# Altium schematic sheet Microcontroller.SchDoc
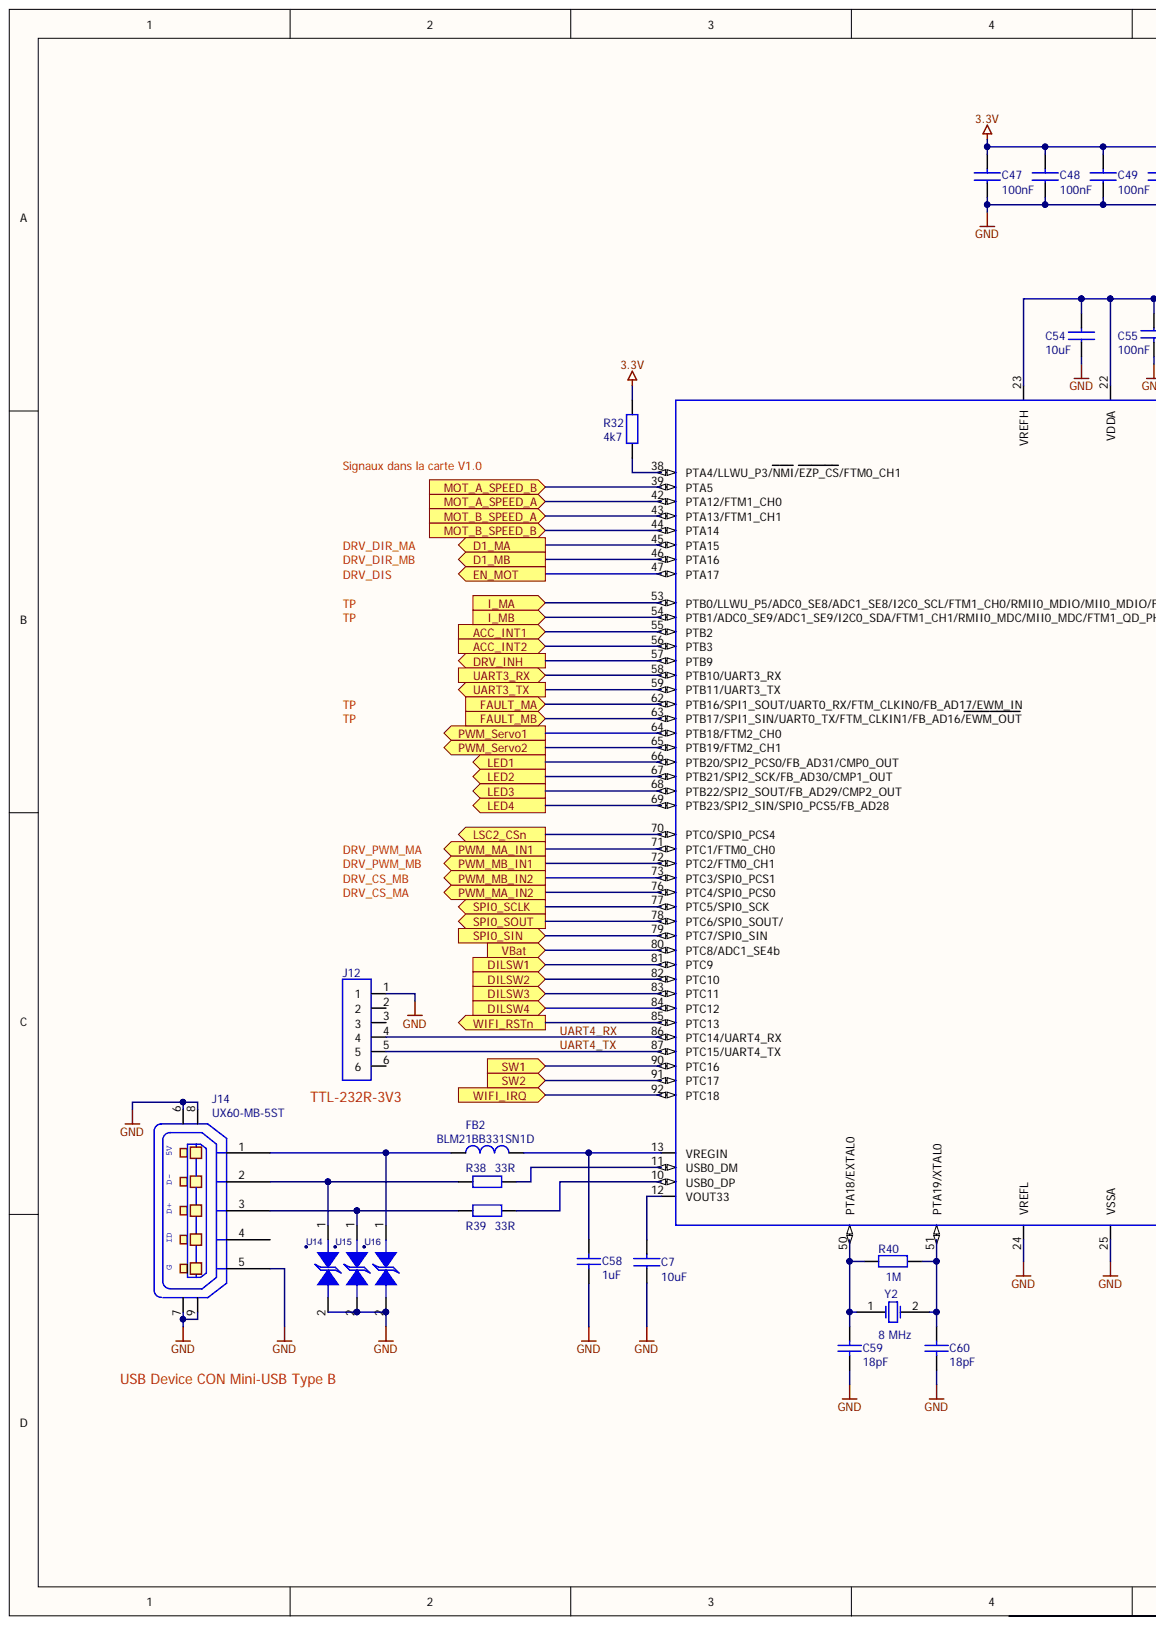
[diagram p0: Microcontroller.SchDoc, left]
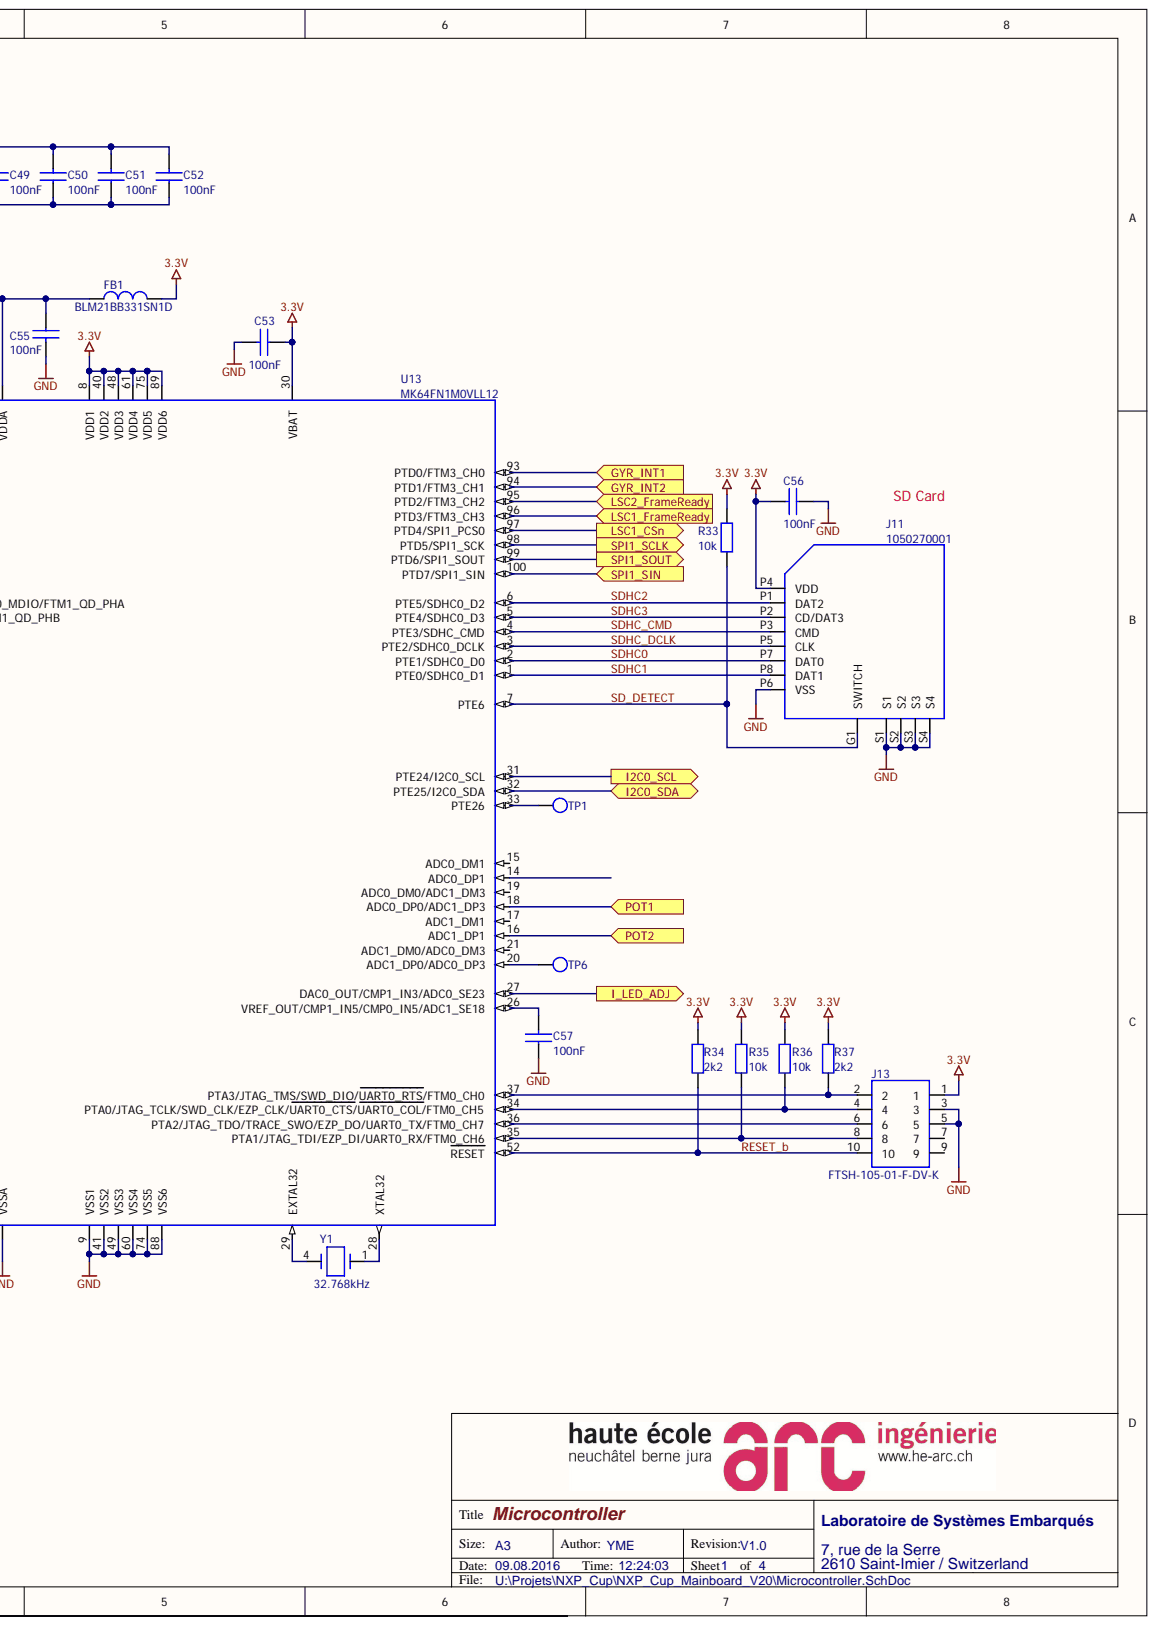
[diagram p1: Microcontroller.SchDoc, right]

## Parts
C7  10uF  [080501]
C47  100nF  [060301]
C48  100nF  [060301]
C49  100nF  [060301]
C50  100nF  [060301]
C51  100nF  [060301]
C52  100nF  [060301]
C53  100nF  [060301]
C54  10uF  [080501]
C55  100nF  [060301]
C56  100nF  [060301]
C57  100nF  [060301]
C58  1uF  [060301]
C59  18pF  [060301]
C60  18pF  [060301]
FB1  BLM21BB331SN1D  [080501]
FB2  BLM21BB331SN1D  [080501]
J11  1050270001  [con8_sdcard_push_sm]
J12  68000-206HLF  [J106A101]  (value not drawn)
J13  FTSH-105-01-F-DV-K  [FTSH-105-01-F-DV-K]
J14  UX60-MB-5ST  [USB/UX60-MB-5ST]
R32  4k7  [060301]
R33  10k  [060301]
R34  2k2  [060301]
R35  10k  [060301]
R36  10k  [060301]
R37  2k2  [060301]
R38  33R  [060301]
R39  33R  [060301]
R40  1M  [060301]
TP1  Test point  [TP_06_10]  (value not drawn)
TP6  Test point  [TP_06_10]  (value not drawn)
U13  MK64FN1M0VLL12  [LQFP100_0P5_14X14]
U14  0402ESDA-MLP  [0402_mlp]  (value not drawn)
U15  0402ESDA-MLP  [0402_mlp]  (value not drawn)
U16  0402ESDA-MLP  [0402_mlp]  (value not drawn)
Y1  value 32.768kHz  [XT-5]  (hidden comment: ECS-.327-12.5-17X-C-TR)
Y2  value 8 MHz  [XT8_2-SMD]  (hidden comment: ABM3-8.000MHZ-D2Y-T)

## Ports
ACC_INT1, ACC_INT2, D1_MA, D1_MB, DILSW1, DILSW2, DILSW3, DILSW4, DRV_INH, EN_MOT, FAULT_MA, FAULT_MB, GYR_INT1, GYR_INT2, I2C0_SCL, I2C0_SDA, I_LED_ADJ, I_MA, I_MB, LED1, LED2, LED3, LED4, LSC1_CSN, LSC1_FRAMEREADY, LSC2_CSN, LSC2_FRAMEREADY, MOT_A_SPEED_A, MOT_A_SPEED_B, MOT_B_SPEED_A, MOT_B_SPEED_B, POT1, POT2, PWM_MA_IN1, PWM_MA_IN2, PWM_MB_IN1, PWM_MB_IN2, PWM_SERVO1, PWM_SERVO2, SPI0_SCLK, SPI0_SIN, SPI0_SOUT, SPI1_SCLK, SPI1_SIN, SPI1_SOUT, SW1, SW2, UART3_RX, UART3_TX, VBAT, WIFI_IRQ, WIFI_RSTN

## Nets
3.3V: C47.2 C48.2 C49.2 C50.2 C51.2 C52.2 C53.1 C56.2 FB1.2 J11.P4(VDD) J13.1 R32.2 R33.1 R34.2 R35.1 R36.1 R37.2 U13.8(VDD1) U13.30(VBAT) U13.40(VDD2) U13.48(VDD3) U13.61(VDD4) U13.75(VDD5) U13.89(VDD6)
GND: C7.1 C47.1 C48.1 C49.1 C50.1 C51.1 C52.1 C53.2 C54.1 C55.2 C56.1 C57.1 C58.2 C59.1 C60.1 J11.P6(VSS) J11.S1 J11.S2 J11.S3 J11.S4 J12.1 J13.3 J13.5 J14.5 J14.6 J14.7 J14.8 J14.9 U13.9(VSS1) U13.24(VREFL) U13.25(VSSA) U13.41(VSS2) U13.49(VSS3) U13.60(VSS4) U13.74(VSS5) U13.88(VSS6) U14.2 U15.2 U16.2
LSC1_CSN: U13.97(PTD4/SPI1_PCS0) ; port LSC1_CSN
LSC1_FRAMEREADY: U13.96(PTD3/FTM3_CH3) ; port LSC1_FRAMEREADY
LSC2_CSN: U13.70(PTC0/SPI0_PCS4) ; port LSC2_CSN
LSC2_FRAMEREADY: U13.95(PTD2/FTM3_CH2) ; port LSC2_FRAMEREADY
PWM_SERVO1: U13.64(PTB18/FTM2_CH0) ; port PWM_SERVO1
PWM_SERVO2: U13.65(PTB19/FTM2_CH1) ; port PWM_SERVO2
VBAT: U13.80(PTC8/ADC1_SE4b) ; port VBAT
WIFI_RSTN: U13.85(PTC13) ; port WIFI_RSTN
ACC_INT1: U13.55(PTB2) ; port ACC_INT1
ACC_INT2: U13.56(PTB3) ; port ACC_INT2
D1_MA: U13.45(PTA15) ; port D1_MA
D1_MB: U13.46(PTA16) ; port D1_MB
DILSW1: U13.81(PTC9) ; port DILSW1
DILSW2: U13.82(PTC10) ; port DILSW2
DILSW3: U13.83(PTC11) ; port DILSW3
DILSW4: U13.84(PTC12) ; port DILSW4
DRV_INH: U13.57(PTB9) ; port DRV_INH
EN_MOT: U13.47(PTA17) ; port EN_MOT
FAULT_MA: U13.62(PTB16/SPI1_SOUT/UART0_RX/FTM_CLKIN0/FB_AD17/EWM_IN) ; port FAULT_MA
FAULT_MB: U13.63(PTB17/SPI1_SIN/UART0_TX/FTM_CLKIN1/FB_AD16/EWM_OUT) ; port FAULT_MB
GYR_INT1: U13.93(PTD0/FTM3_CH0) ; port GYR_INT1
GYR_INT2: U13.94(PTD1/FTM3_CH1) ; port GYR_INT2
I2C0_SCL: U13.31(PTE24/I2C0_SCL) ; port I2C0_SCL
I2C0_SDA: U13.32(PTE25/I2C0_SDA) ; port I2C0_SDA
I_LED_ADJ: U13.27(DAC0_OUT/CMP1_IN3/ADC0_SE23) ; port I_LED_ADJ
I_MA: U13.53(PTB0/LLWU_P5/ADC0_SE8/ADC1_SE8/I2C0_SCL/FTM1_CH0/RMII0_MDIO/MII0_MDIO/FTM1_QD_PHA) ; port I_MA
I_MB: U13.54(PTB1/ADC0_SE9/ADC1_SE9/I2C0_SDA/FTM1_CH1/RMII0_MDC/MII0_MDC/FTM1_QD_PHB) ; port I_MB
LED1: U13.66(PTB20/SPI2_PCS0/FB_AD31/CMP0_OUT) ; port LED1
LED2: U13.67(PTB21/SPI2_SCK/FB_AD30/CMP1_OUT) ; port LED2
LED3: U13.68(PTB22/SPI2_SOUT/FB_AD29/CMP2_OUT) ; port LED3
LED4: U13.69(PTB23/SPI2_SIN/SPI0_PCS5/FB_AD28) ; port LED4
MOT_A_SPEED_A: U13.42(PTA12/FTM1_CH0) ; port MOT_A_SPEED_A
MOT_A_SPEED_B: U13.39(PTA5) ; port MOT_A_SPEED_B
MOT_B_SPEED_A: U13.43(PTA13/FTM1_CH1) ; port MOT_B_SPEED_A
MOT_B_SPEED_B: U13.44(PTA14) ; port MOT_B_SPEED_B
POT1: U13.18(ADC0_DP0/ADC1_DP3) ; port POT1
POT2: U13.16(ADC1_DP1) ; port POT2
PWM_MA_IN1: U13.71(PTC1/FTM0_CH0) ; port PWM_MA_IN1
PWM_MA_IN2: U13.76(PTC4/SPI0_PCS0) ; port PWM_MA_IN2
PWM_MB_IN1: U13.72(PTC2/FTM0_CH1) ; port PWM_MB_IN1
PWM_MB_IN2: U13.73(PTC3/SPI0_PCS1) ; port PWM_MB_IN2
RESET_B: J13.10 R34.1 U13.52(RESET)
SD_DETECT: J11.G1(SWITCH) R33.2 U13.7(PTE6)
SDHC0: J11.P7(DAT0) U13.2(PTE1/SDHC0_D0)
SDHC1: J11.P8(DAT1) U13.1(PTE0/SDHC0_D1)
SDHC2: J11.P1(DAT2) U13.6(PTE5/SDHC0_D2)
SDHC3: J11.P2(CD/DAT3) U13.5(PTE4/SDHC0_D3)
SDHC_CMD: J11.P3(CMD) U13.4(PTE3/SDHC_CMD)
SDHC_DCLK: J11.P5(CLK) U13.3(PTE2/SDHC0_DCLK)
SPI0_SCLK: U13.77(PTC5/SPI0_SCK) ; port SPI0_SCLK
SPI0_SIN: U13.79(PTC7/SPI0_SIN) ; port SPI0_SIN
SPI0_SOUT: U13.78(PTC6/SPI0_SOUT/) ; port SPI0_SOUT
SPI1_SCLK: U13.98(PTD5/SPI1_SCK) ; port SPI1_SCLK
SPI1_SIN: U13.100(PTD7/SPI1_SIN) ; port SPI1_SIN
SPI1_SOUT: U13.99(PTD6/SPI1_SOUT) ; port SPI1_SOUT
SW1: U13.90(PTC16) ; port SW1
SW2: U13.91(PTC17) ; port SW2
UART3_RX: U13.58(PTB10/UART3_RX) ; port UART3_RX
UART3_TX: U13.59(PTB11/UART3_TX) ; port UART3_TX
UART4_RX: J12.4 U13.86(PTC14/UART4_RX)
UART4_TX: J12.5 U13.87(PTC15/UART4_TX)
WIFI_IRQ: U13.92(PTC18) ; port WIFI_IRQ
NetC7_2: C7.2 U13.12(VOUT33)
NetC54_2: C54.2 C55.1 FB1.1 U13.22(VDDA) U13.23(VREFH)
NetC57_2: C57.2 U13.26(VREF_OUT/CMP1_IN5/CMP0_IN5/ADC1_SE18)
NetC58_1: C58.1 FB2.2 U13.13(VREGIN)
NetC59_2: C59.2 R40.1 U13.50(PTA18/EXTAL0) Y2.1
NetC60_2: C60.2 R40.2 U13.51(PTA19/XTAL0) Y2.2
NetFB2_1: FB2.1 J14.1 U16.1
NetJ13_2: J13.2 R37.1 U13.37(PTA3/JTAG_TMS/SWD_DIO/UART0_RTS/FTM0_CH0)
NetJ13_4: J13.4 R36.2 U13.34(PTA0/JTAG_TCLK/SWD_CLK/EZP_CLK/UART0_CTS/UART0_COL/FTM0_CH5)
NetJ13_6: J13.6 U13.36(PTA2/JTAG_TDO/TRACE_SWO/EZP_DO/UART0_TX/FTM0_CH7)
NetJ13_8: J13.8 R35.2 U13.35(PTA1/JTAG_TDI/EZP_DI/UART0_RX/FTM0_CH6)
NetJ14_2: J14.2 R38.1 U14.1
NetJ14_3: J14.3 R39.1 U15.1
NetR32_1: R32.1 U13.38(PTA4/LLWU_P3/NMI/EZP_CS/FTM0_CH1)
NetR38_2: R38.2 U13.11(USB0_DM)
NetR39_2: R39.2 U13.10(USB0_DP)
NetTP1_1: TP1.1 U13.33(PTE26)
NetTP6_1: TP6.1 U13.20(ADC1_DP0/ADC0_DP3)
NetU13_28: U13.28(XTAL32) Y1.1
NetU13_29: U13.29(EXTAL32) Y1.4

Not connected: J12 2 3 6; J13 7 9; J14 4; U13 14(ADC0_DP1) 15(ADC0_DM1) 17(ADC1_DM1) 19(ADC0_DM0/ADC1_DM3) 21(ADC1_DM0/ADC0_DM3)

## Library data of the parts
C7 C54 = CAPA: CAP CER 10UF 16V 20% X6S 0805; Component Kind: Standard; Supplier 1: Digi-Key; Supplier Part Number 1: 445-7612-2-ND; Value: 10uF; Library Name: EISI.SchLib
  C54: Signal Integrity: Cap Semi; Simulation: CAP
C47-C53 C55-C57 = CAPA: CAP CER 0.1UF 25V 10% X7R 0603; Component Kind: Standard; Supplier 1: Digi-Key; Supplier Part Number 1: 445-1316-1-ND; Value: 100nF; Voltage: 25V; Library Name: EISI.SchLib
C58 = Cap Semi: CAP CER 1UF 16V 10% X7R 0603; Component Kind: Standard; Library Name: Miscellaneous Devices.IntLib; Signal Integrity: Cap Semi; Simulation: CAP; Supplier 1: Digi-Key; Supplier Part Number 1: 399-7847-6-ND; Value: 1uF
C59 C60 = Cap Semi: CAP CER 18PF 50V 5% NP0 0603; Component Kind: Standard; Library Name: Miscellaneous Devices.IntLib; Signal Integrity: Cap Semi; Simulation: CAP; Supplier 1: Digi-Key; Supplier Part Number 1: 399-1052-6-ND; Value: 18pF
FB1 FB2 = Ferrite Bead: EMI Filter Beads, Chips & Arrays 0805 330 OHM; Value: 300R@100MHz; Component Kind: Standard; Supplier 1: Mouser; Supplier Part Number 1: 81-BLM21BB331SN1D; Library Name: EISI.SchLib
J11 = CON8_SDCARD_SW: CON 8 SD CARD PUSH SMT 1.1MM SP 75H AU; Component Kind: Standard; Library Name: SCH-28163-1.SCHLIB; Supplier 1: Digi-Key; Supplier Part Number 1: WM6825CT-ND
J12 = CON6: CONN HEADER 6POS .100 STR 15AU; Component Kind: Standard; Supplier 1: Digi-Key; Supplier Part Number 1: 609-3256-ND; Library Name: EISI.SchLib
J13 = CON10A: CONN HEADER 10POS DUAL .05" SMD; Component Kind: Standard; Supplier 1: Digi-Key; Supplier Part Number 1: FTSH-105-01-F-DV-K-ND; Library Name: EISI.SchLib
J14 = USB_MINI_AB_RECEPTACLE: CONN RECEPT MINI USB2.0 5POS; Component Kind: Standard; Supplier 1: Digi-Key; Supplier Part Number 1: H2959CT-ND
R32 = RES: RES 4.7K OHM 1/10W 1% 0603 SMD; Component Kind: Standard; Supplier 1: Digi-Key; Supplier Part Number 1: P4.70KHTR-ND; Value: 4k7; Library Name: EISI.SchLib
R33 R35 R36 = RES: RES 10K OHM 1/10W .1% 0603 SMD; Component Kind: Standard; Supplier 1: Digi-Key; Supplier Part Number 1: P10KDBTR-ND; Value: 10k; Library Name: EISI.SchLib
R34 R37 = RES: RES 2.20K OHM 1/10W 1% 0603 SMD; Component Kind: Standard; Supplier 1: Digi-Key; Supplier Part Number 1: 311-2.20KHRCT-ND; Value: 2k2; Library Name: EISI.SchLib
R38 R39 = RES: RES 33.0 OHM 1/10W 1% 0603 SMD; Component Kind: Standard; Supplier 1: Digi-Key; Supplier Part Number 1: 311-33.0HRCT-ND; Value: 33R; Library Name: EISI.SchLib
R40 = RES: RES 1.00M OHM 1/10W 1% 0603 SMD; Component Kind: Standard; Supplier 1: Digi-Key; Supplier Part Number 1: 311-1.00MHRCT-ND; Value: 1M; Library Name: EISI.SchLib
TP1 TP6 = TP: Component Kind: Standard; Description: Test point; DNP: DNP; Library Name: EISI.SchLib
U13 = K64FN1M0VLL12_100P: IC MCU 1MB FLASH 120MHZ 1.71-3.6V LQFP100; Component Kind: Standard; Library Name: SCH-28163-1.SCHLIB; Supplier 1: Digi-Key; Supplier Part Number 1: MK64FN1M0VLL12-ND
U14-U16 = DIODE_TVS_BIDIR: SUPPRESSOR ESD 30VDC 0402 SMD; Component Kind: Standard; Supplier 1: Digi-Key; Supplier Part Number 1: 283-2792-1-ND
Y1 = Crystal_32: CRYSTAL 32.768 KHZ 12.5PF SMD; Component Kind: Standard; Supplier 1: Digi-Key; Supplier Part Number 1: XC1912CT-ND
Y2 = XTAL: CRYSTAL 8MHZ 18PF SMD; Component Kind: Standard; Library Name: Miscellaneous Devices.IntLib; Simulation: XTAL; Supplier 1: Digi-Key; Supplier Part Number 1: 535-10630-6-ND; Value: 8 MHz
